# Altium schematic sheet Power_Sensing.SchDoc(Power_Sensing)
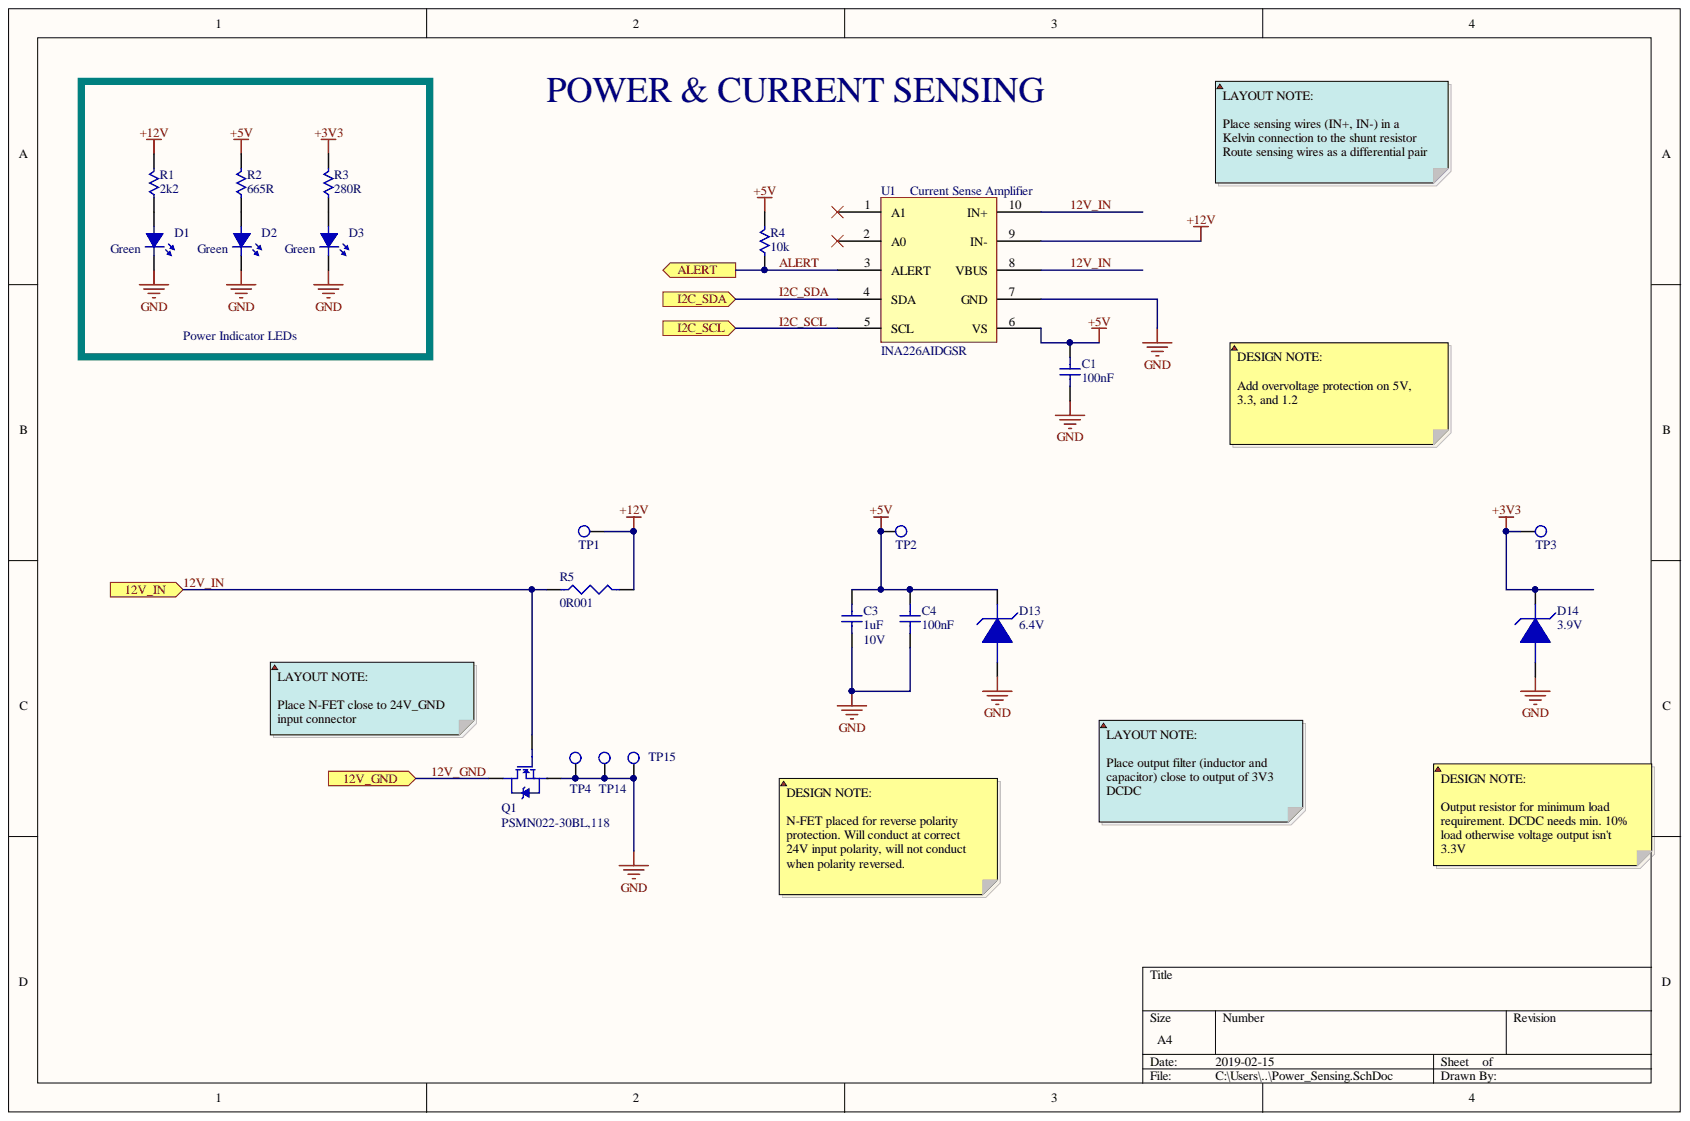
[diagram p0: Power_Sensing.SchDoc]

## Parts
C1  100nF  [C0402]
C3  1uF  Voltage Rating (DC): 10V  [C0402]
C4  100nF  [C0402]
D1  Green  [LED_0603]
D2  Green  [LED_0603]
D3  Green  [LED_0603]
D13  6.4V  [DIODE_TVS]
D14  3.9V  [DIODE_TVS]
Q1  PSMN022-30BL,118  [D2PAK_FETs]
R1  2k2  [R0402]
R2  665R  [R0402]
R3  280R  [R0402]
R4  10k  [R0402]
R5  0R001  [R2512]
TP1  5015  [TP_HOOK]  (value not drawn)
TP2  5015  [TP_HOOK]  (value not drawn)
TP3  5015  [TP_HOOK]  (value not drawn)
TP4  5015  [TP_HOOK]  (value not drawn)
TP14  5015  [TP_HOOK]  (value not drawn)
TP15  5015  [TP_HOOK]  (value not drawn)
U1  INA226AIDGSR  [10-VSSOP_INA226]

## Ports
12V_GND, 12V_IN, ALERT, I2C_SCL, I2C_SDA

## Nets
+3V3: D14.1 R3.2 TP3.1
+5V: C1.2 C3.2 C4.2 D13.1 R2.2 R4.2 TP2.1 U1.6(VS)
+12V: R1.2 R5.2 TP1.1 U1.9(IN-)
GND: C1.1 C3.1 C4.1 D1.2 D2.2 D3.2 D13.2 D14.2 Q1.3 TP4.1 TP14.1 TP15.1 U1.7(GND)
12V_GND: Q1.4 ; port 12V_GND
12V_IN: Q1.1 R5.1 U1.8(VBUS) U1.10(IN+) ; port 12V_IN
ALERT: R4.1 U1.3(ALERT) ; port ALERT
I2C_SCL: U1.5(SCL) ; port I2C_SCL
I2C_SDA: U1.4(SDA) ; port I2C_SDA
NetD1_1: D1.1 R1.1
NetD2_1: D2.1 R2.1
NetD3_1: D3.1 R3.1

Not connected: U1 1(A1) 2(A0)

## Library data of the parts
C1 C4 = CL05A104MP5NNNC: CAP MLCC 0.1uF 10V X5R 0402 20% SMD 0402 85C Paper T/R; Manufacturer: Samsung; Width: 0.02inch; Voltage Rating (DC): 10V; Voltage Rating: 10V; Tolerance: 20%; Thickness: 0.022inch; Supplier Part Number 1: 1276-1443-1-ND; Supplier 1: Digi-Key; Stock 1: 100000; Radiation Hardening: No; Pricing 1: 1=0.1, 10=0.024, 100=0.0106, 500=0.0076, 1000=0.006, 2500=0.0054, 5000=0.005 (USD); Packaging: Tape and Reel; Mount: Surface Mount; Min Operating Temperature: -55°C; Max Operating Temperature: 85°C; Manufacturer Part Number: CL05A104MP5NNNC; Length: 1mm; Lead Free: Lead Free; Height: 0.5mm; Dielectric: X5R; Depth: 0.5mm; ComponentLink1URL: https://media.digikey.com/pdf/Data%20Sheets/Samsung%20PDFs/CL_Series_MLCC_ds.pdf; ComponentLink1Description: https://media.digikey.com/pdf/Data%20Sheets/Samsung%20PDFs/CL_Series_MLCC_ds.pdf; Component Kind: Standard; Case Code (Metric): 1005; Case Code (Imperial): 0402; Case/Package: 0402; Capacitance: 100nF; Library Name: PHANTOM_LIBRARY.SchLib
C3 = CL05A105MP5NNNC: CAP MLCC 1uF 10V 0402 X5R 20% SMD 0402 85C Paper T/R; Manufacturer: Samsung; Width: 0.02inch; Voltage Rating: 10V; Tolerance: 20%; Thickness: 0.022inch; Supplier Part Number 1: 1276-1448-1-ND; Supplier 1: Digi-Key; Stock 1: 100000; Radiation Hardening: No; Pricing 1: 1=0.1, 10=0.031, 100=0.014, 500=0.01, 1000=0.0079, 2500=0.0072, 5000=0.0066 (USD); Packaging: Tape and Reel; Package Quantity: 1; Mount: Surface Mount; Min Operating Temperature: -55°C; Max Operating Temperature: 85°C; Manufacturer Part Number: CL05A105MP5NNNC; Length: 1mm; Lead Free: Lead Free; Height: 0.5mm; Dielectric: X5R; Depth: 0.5mm; ComponentLink1URL: https://media.digikey.com/pdf/Data%20Sheets/Samsung%20PDFs/CL_Series_MLCC_ds.pdf; ComponentLink1Description: https://media.digikey.com/pdf/Data%20Sheets/Samsung%20PDFs/CL_Series_MLCC_ds.pdf; Component Kind: Standard; Case Code (Metric): 1005; Case Code (Imperial): 0402; Case/Package: 0402; Capacitance: 1uF; Library Name: PHANTOM_LIBRARY.SchLib
D1-D3 = 150060VS75000: LED GREEN CLEAR 0603 SMD  LED, 0603, B GREEN,  40MCD, 570NM; Manufacturer: Wurth Electronics; Viewing Angle: 140°; Test Current: 20mA; Lens Style: Clear,Rectangular; Forward Voltage: 2V; Forward Current: 30mA; Width: 0.8mm; Wavelength: 570nm; Supplier Part Number 1: 732-4980-1-ND; Supplier 1: Digi-Key; Stock 1: 207370; REACH SVHC: Unknown; Pricing 1: 1=0.14, 50=0.129, 100=0.11, 500=0.095 (USD); Packaging: Tape and Reel; Package Quantity: 1; Number of Pins: 2; Mount: Surface Mount; Min Operating Temperature: -40°C; Max Operating Temperature: 85°C; Manufacturer Part Number: 150060VS75000; Luminous Intensity: 40mcd; Length: 1.6mm; Height: 0.7mm; Dominant Wavelength: 570nm; ComponentLink4URL: http://www.produktinfo.conrad.com/datenblaetter/750000-774999/759431-da-01-en-CHIPLED_0603_W_KL_H_GRUEN_150060VS7500.pdf; ComponentLink4Description: http://www.produktinfo.conrad.com/datenblaetter/750000-774999/759431-da-01-en-CHIPLED_0603_W_KL_H_GRUEN_150060VS7500.pdf; ComponentLink3URL: https://www.distrelec.biz/Web/Downloads/_t/ds/150060VS75000_eng_tds.pdf?mime=application%2Fpdf; ComponentLink3Description: https://www.distrelec.biz/Web/Downloads/_t/ds/150060VS75000_eng_tds.pdf?mime=application%2Fpdf; ComponentLink2URL: https://katalog.we-online.de/led/datasheet/150060VS75000.pdf; ComponentLink2Description: https://katalog.we-online.de/led/datasheet/150060VS75000.pdf; ComponentLink1URL: http://www.farnell.com/datasheets/1911347.pdf; ComponentLink1Description: http://www.farnell.com/datasheets/1911347.pdf; Component Kind: Standard; Color: Green; Case Code (Metric): 1608; Case Code (Imperial): 0603; Case/Package: 0603; Library Name: PHANTOM_LIBRARY.SchLib
D13 = SMAJ5.0A: DIODE TVS_6V4 5VWM 9.2VC SMA; Manufacturer: Littelfuse; Voltage Rating (DC): 5V; Test Current: 10mA; Power Line Protection: No; Power Dissipation: 400W; Polarity: Unidirectional; Peak Pulse Current: 43.5A; Number of Unidirectional Channels: 1; Max Reverse Leakage Current: 800uA; Max Power Dissipation: 3.3W; Max Breakdown Voltage: 7V; Element Configuration: Single; Clamping Voltage: 9.2V; Width: 2.79mm; Termination: SMD/SMT; Supplier Part Number 1: SMAJ5.0ALFCT-ND; Supplier 1: Digi-Key; Stock 1: 78251; Reverse Standoff Voltage: 5V; Reverse Breakdown Voltage: 6.4V; REACH SVHC: No SVHC; Radiation Hardening: No; Pricing 1: 1=0.51, 10=0.359, 25=0.2948, 100=0.2359, 250=0.1716, 500=0.1394, 1000=0.1072, 2500=0.0965 (USD); Peak Pulse Power: 400W; Packaging: Tape and Reel; Package Quantity: 5000; Operating Supply Voltage: 5V; Number of Pins: 2; Number of Elements: 1; Number of Channels: 1; Mount: Surface Mount; Min Operating Temperature: -65°C; Min Breakdown Voltage: 6.4V; Max Surge Current: 43.5A; Max Operating Temperature: 150°C; Manufacturer Part Number: SMAJ5.0A; Length: 4.5mm; Leakage Current: 800uA; Lead Free: Lead Free; Height: 2.087mm; Direction: Unidirectional; ComponentLink8URL: https://datasheet.ciiva.com/8062/littelfuse-tvs-diode-smaj-8062271.pdf; ComponentLink8Description: https://datasheet.ciiva.com/8062/littelfuse-tvs-diode-smaj-8062271.pdf; ComponentLink7URL: https://datasheet.ciiva.com/4507/littelfuse-tvs-diode-smaj-23503-4507964.pdf; ComponentLink7Description: https://datasheet.ciiva.com/4507/littelfuse-tvs-diode-smaj-23503-4507964.pdf; ComponentLink6URL: https://datasheet.ciiva.com/28473/607617-28473433.pdf; ComponentLink6Description: https://datasheet.ciiva.com/28473/607617-28473433.pdf; ComponentLink5URL: https://datasheet.ciiva.com/25624/0900766b810f4edb-25624839.pdf; ComponentLink5Description: https://datasheet.ciiva.com/25624/0900766b810f4edb-25624839.pdf; ComponentLink4URL: https://datasheet.ciiva.com/16380/littelfuse-tvs-diode-smaj-16380982.pdf; ComponentLink4Description: https://datasheet.ciiva.com/16380/littelfuse-tvs-diode-smaj-16380982.pdf; ComponentLink3URL: https://datasheet.ciiva.com/330/littelfuse-tvs-diode-smaj-datasheet-pdf-330726.pdf; ComponentLink3Description: https://datasheet.ciiva.com/330/littelfuse-tvs-diode-smaj-datasheet-pdf-330726.pdf; ComponentLink2URL: https://datasheet.ciiva.com/330/littelfuse-tvs-diode-smaj-datasheet-pdf-330725.pdf; ComponentLink2Description: https://datasheet.ciiva.com/330/littelfuse-tvs-diode-smaj-datasheet-pdf-330725.pdf; ComponentLink1URL: https://datasheet.ciiva.com/330/littelfuse-tvs-diode-smaj-datasheet-pdf-330724.pdf; ComponentLink1Description: https://datasheet.ciiva.com/330/littelfuse-tvs-diode-smaj-datasheet-pdf-330724.pdf; Component Kind: Standard; Breakdown Voltage: 6.4V; Library Name: PHANTOM_LIBRARY.SchLib
D14 = 1SMA5915BT3G: DIODE ZENER 3.9V 1.5W SMA; Manufacturer: ON Semiconductor; Zener Current: 385mA; Voltage Tolerance: 5%; Test Current: 96.1mA; Power Dissipation: 500mW; Peak Reverse Current: 12.5uA; Impedance: 7.5R; Forward Voltage: 1.5V; ESD Protection: Yes; Element Configuration: Single; Breakdown Voltage: 12V; Zener Voltage: 3.9V; Working Voltage: 3.9V; Width: 2.92mm; Voltage Rating (DC): 3.9V; Tolerance: 5%; Supplier Part Number 1: 1SMA5915BT3GOSCT-ND; Supplier 1: Digi-Key; Stock 1: 21605; REACH SVHC: No SVHC; Radiation Hardening: No; Pricing 1: 1=0.41, 10=0.335, 100=0.2284, 500=0.1713, 1000=0.1285 (USD); Power Rating: 1.5W; Packaging: Tape and Reel; Package Quantity: 1; Number of Pins: 2; Min Operating Temperature: -65°C; Max Reverse Leakage Current: 12.5uA; Max Power Dissipation: 1.5W; Max Operating Temperature: 150°C; Manufacturer Part Number: 1SMA5915BT3G; Length: 4.57mm; Lead Free: Lead Free; Height: 2.05mm; Halogen Free: Halogen Free; Contact Plating: Tin,Matte; ComponentLink7URL: https://datasheet.ciiva.com/10639/1sma5913bt3-d-10639017.pdf; ComponentLink7Description: https://datasheet.ciiva.com/10639/1sma5913bt3-d-10639017.pdf; ComponentLink6URL: https://datasheet.ciiva.com/16311/1sma5913bt3-d-16311880.pdf; ComponentLink6Description: https://datasheet.ciiva.com/16311/1sma5913bt3-d-16311880.pdf; ComponentLink5URL: https://datasheet.ciiva.com/8026/1sma5913bt3-d-8026919.pdf; ComponentLink5Description: https://datasheet.ciiva.com/8026/1sma5913bt3-d-8026919.pdf; ComponentLink4URL: https://datasheet.ciiva.com/895/1sma5913bt3-d-895335.pdf; ComponentLink4Description: https://datasheet.ciiva.com/895/1sma5913bt3-d-895335.pdf; ComponentLink3URL: https://datasheet.ciiva.com/895/1sma5913bt3-d-895334.pdf; ComponentLink3Description: https://datasheet.ciiva.com/895/1sma5913bt3-d-895334.pdf; ComponentLink2URL: https://datasheet.ciiva.com/26092/1sma5913bt3-d-26092405.pdf; ComponentLink2Description: https://datasheet.ciiva.com/26092/1sma5913bt3-d-26092405.pdf; ComponentLink1URL: https://datasheet.ciiva.com/895/1sma5913bt3-d-895333.pdf; ComponentLink1Description: https://datasheet.ciiva.com/895/1sma5913bt3-d-895333.pdf; Component Kind: Standard; Case/Package: SMA; Library Name: PHANTOM_LIBRARY.SchLib
Q1 = PSMN022-30BL,118: MOSFET N-CH 30V 30A 22.6mOhm Rds(ON); Manufacturer: NXP Semiconductors; Turn-On Delay Time: 12ns; Turn-Off Delay Time: 17ns; Rise Time: 29ns; Rds On Max: 22.6mR; Power Dissipation: 41W; Number of Elements: 1; Input Capacitance: 447pF; Gate to Source Voltage (Vgs): 20V; Fall Time: 7ns; Element Configuration: Single; Supplier Part Number 1: 1727-7117-1-ND; Supplier 1: Digi-Key; Stock 1: 8400; Radiation Hardening: No; Pricing 1: 1=0.99, 10=0.867, 100=0.6687 (USD); Packaging: Tape and Reel; Package Quantity: 1; Number of Pins: 3; Min Operating Temperature: -55°C; Max Power Dissipation: 41W; Max Operating Temperature: 175°C; Max Dual Supply Voltage: 30V; Manufacturer Part Number: PSMN022-30BL,118; Lead Free: Lead Free; Drain to Source Voltage (Vdss): 30V; Drain to Source Resistance: 22.6mR; Drain to Source Breakdown Voltage: 30V; Continuous Drain Current (ID): 30A; Contact Plating: Tin; ComponentLink1URL: http://www.nxp.com/documents/data_sheet/PSMN022-30BL.pdf; ComponentLink1Description: http://www.nxp.com/documents/data_sheet/PSMN022-30BL.pdf; Component Kind: Standard; Case/Package: SOT; Library Name: PHANTOM_LIBRARY.SchLib
R1 = RC0402JR-072K2L: RES SMD 2.2K OHM 5% 1/16W 0402; Manufacturer: Yageo; Working Voltage: 50V; Temperature Coefficient: 100ppm/°C; Resistance: 2.2kR; Power Rating: 63mW; Width: 0.02inch; Weight: 2.3E-05oz; Voltage Rating: 50V; Tolerance: 5%; Termination: SMD/SMT; Supplier Part Number 1: 311-2.2KJRCT-ND; Supplier 1: Digi-Key; Stock 1: 7890813; REACH SVHC: Unknown; Pricing 1: 1=0.1, 10=0.018, 100=0.0073, 1000=0.0033, 2500=0.0029, 5000=0.0024 (USD); Packaging: Tape and Reel; Package Quantity: 1; Number of Terminations: 2; Min Operating Temperature: -55°C; Military Standard: Not; Max Power Dissipation: 63mW; Max Operating Temperature: 155°C; Manufacturer Part Number: RC0402JR-072K2L; Length: 1mm; Lead Free: Lead Free; Height: 0.016inch; Features: Moisture Resistant; Depth: 0.5mm; Composition: Thick Film; Component Kind: Standard; Case Code (Metric): 1005; Case Code (Imperial): 0402; Case/Package: 0402; Library Name: PHANTOM_LIBRARY.SchLib
R2 = RC0402FR-07665RL: RES SMD 665 OHM 1% 0402 1/16W; Manufacturer: Yageo; Voltage Rating: 50V; Temperature Coefficient: 100ppm/°C; Power Rating: 62.5mW; Max Power Dissipation: 63mW; Width: 0.02inch; Tolerance: 1%; Termination: SMD/SMT; Supplier Part Number 1: YAG3208CT-ND; Supplier 1: Digi-Key; Stock 1: 268997; Resistance: 665R; Pricing 1: 1=0.1, 10=0.016, 100=0.0067, 1000=0.003, 2500=0.0026, 5000=0.0022 (USD); Packaging: Tape and Reel; Number of Terminations: 2; Min Operating Temperature: -55°C; Military Standard: Not; Max Operating Temperature: 155°C; Manufacturer Part Number: RC0402FR-07665RL; Length: 1mm; Lead Free: Lead Free; Height: 0.016inch; Features: Moisture Resistant; Depth: 0.5mm; Contact Plating: Matte,Tin; Composition: Thick Film; ComponentLink1URL: http://www.yageo.com/documents/recent/PYu-RC0402_51_RoHS_L_6.pdf; ComponentLink1Description: http://www.yageo.com/documents/recent/PYu-RC0402_51_RoHS_L_6.pdf; Component Kind: Standard; Case Code (Metric): 1005; Case Code (Imperial): 0402; Case/Package: 0402; Library Name: PHANTOM_LIBRARY.SchLib
R3 = RC0402FR-07280RL: RES SMD 280 OHM 1% 1/16W 0402; Manufacturer: Yageo; Voltage Rating (DC): 50V; Temperature Coefficient: 100ppm/°C; Power Rating: 62.5mW; Max Power Dissipation: 63mW; Width: 0.5mm; Weight: 2.3E-05oz; Voltage Rating: 50V; Tolerance: 1%; Termination: SMD/SMT; Supplier Part Number 1: 311-280LRCT-ND; Supplier 1: Digi-Key; Stock 1: 282493; Resistance: 280R; Pricing 1: 1=0.1, 10=0.016, 100=0.0067, 1000=0.003, 2500=0.0026, 5000=0.0022 (USD); Packaging: Tape and Reel; Package Quantity: 1; Number of Terminations: 2; Min Operating Temperature: -55°C; Military Standard: Not; Max Operating Temperature: 155°C; Manufacturer Part Number: RC0402FR-07280RL; Length: 1mm; Lead Free: Lead Free; Height: 0.016inch; Features: Moisture Resistant; Depth: 0.5mm; Composition: Thick Film; ComponentLink3URL: http://www.yageo.com/documents/recent/PYu-RC0402_51_RoHS_L_6_r.pdf; ComponentLink3Description: http://www.yageo.com/documents/recent/PYu-RC0402_51_RoHS_L_6_r.pdf; ComponentLink2URL: http://www.yageo.com/documents/recent/PYu-RC_Group_51_RoHS_L_9.pdf; ComponentLink2Description: http://www.yageo.com/documents/recent/PYu-RC_Group_51_RoHS_L_9.pdf; ComponentLink1URL: http://www.yageo.com.tw/exep/pages/download/literatures/PYu-R_INT-thick_7.pdf; ComponentLink1Description: http://www.yageo.com.tw/exep/pages/download/literatures/PYu-R_INT-thick_7.pdf; Component Kind: Standard; Case Code (Metric): 1005; Case Code (Imperial): 0402; Case/Package: 0402; Library Name: PHANTOM_LIBRARY.SchLib
R4 = RC0402JR-0710KL: RES SMD 10K OHM 5% 1/16W 0402; Manufacturer: Yageo; Voltage Rating: 50V; Temperature Coefficient: 100ppm/°C; Resistance: 10kR; Power Rating: 62.5mW; Max Power Dissipation: 63mW; Width: 0.02inch; Tolerance: 5%; Termination: SMD/SMT; Supplier Part Number 1: 311-10KJRCT-ND; Supplier 1: Digi-Key; Stock 1: 47303622; REACH SVHC: Unknown; Pricing 1: 1=0.1, 10=0.018, 100=0.0073, 1000=0.0033, 2500=0.0029, 5000=0.0024 (USD); Packaging: Tape and Reel; Package Quantity: 1; Number of Terminations: 2; Min Operating Temperature: -55°C; Military Standard: Not; Max Operating Temperature: 155°C; Manufacturer Part Number: RC0402JR-0710KL; Length: 1mm; Lead Free: Lead Free; Height: 0.016inch; Features: Moisture Resistant; Depth: 0.5mm; Composition: Thick Film; Component Kind: Standard; Case Code (Metric): 1005; Case Code (Imperial): 0402; Case/Package: 0402; Library Name: PHANTOM_LIBRARY.SchLib
R5 = ERJ-M1WTF1M0U: Res Thick Film 2512 0.001 Ohm 1% 1W 250ppm/C to 450ppm/C Molded SMD Embossed Carrier T/R; Manufacturer: Panasonic ECG; Temperature Coefficient: 450ppm/°C; Resistance: 1mR; Width: 0.126inch; Tolerance: 1%; Termination: SMD/SMT; Supplier Part Number 1: P1.0NDCT-ND; Supplier 1: Digi-Key; Stock 1: 36740; REACH SVHC: No SVHC; Radiation Hardening: No; Pricing 1: 1=0.55, 10=0.456, 25=0.3908, 50=0.3516, 100=0.3061, 250=0.2735, 500=0.2214, 1000=0.1791 (USD); Power Rating: 1W; Packaging: Tape and Reel; Package Quantity: 1; Number of Terminations: 2; Number of Pins: 2; Mount: Surface Mount; Min Operating Temperature: -55°C; Military Standard: Not; Max Power Dissipation: 1W; Max Operating Temperature: 170°C; Manufacturer Part Number: ERJ-M1WTF1M0U; Length: 6.4mm; Lead Free: Lead Free; Height: 0.8mm; Depth: 3.2mm; Contact Plating: Tin; Composition: Thick Film,Metal Strip,Metal Element; ComponentLink11URL: https://datasheet.ciiva.com/16785/70260812-16785566.pdf; ComponentLink11Description: https://datasheet.ciiva.com/16785/70260812-16785566.pdf; ComponentLink10URL: https://datasheet.ciiva.com/30206/aoa0000ce7-30206797.pdf; ComponentLink10Description: https://datasheet.ciiva.com/30206/aoa0000ce7-30206797.pdf; ComponentLink9URL: https://datasheet.ciiva.com/17215/aoa0000ce7-17215229.pdf; ComponentLink9Description: https://datasheet.ciiva.com/17215/aoa0000ce7-17215229.pdf; ComponentLink8URL: https://datasheet.ciiva.com/5996/39800-5996507.pdf; ComponentLink8Description: https://datasheet.ciiva.com/5996/39800-5996507.pdf; ComponentLink7URL: https://datasheet.ciiva.com/16788/70260812-16788394.pdf; ComponentLink7Description: https://datasheet.ciiva.com/16788/70260812-16788394.pdf; ComponentLink6URL: https://datasheet.ciiva.com/16512/aoa0000ce7-16512553.pdf; ComponentLink6Description: https://datasheet.ciiva.com/16512/aoa0000ce7-16512553.pdf; ComponentLink5URL: https://datasheet.ciiva.com/24072/aoa0000ce7-60136-24072248.pdf; ComponentLink5Description: https://datasheet.ciiva.com/24072/aoa0000ce7-60136-24072248.pdf; ComponentLink4URL: https://datasheet.ciiva.com/16216/aoa0000ce7-16216983.pdf; ComponentLink4Description: https://datasheet.ciiva.com/16216/aoa0000ce7-16216983.pdf; ComponentLink3URL: https://datasheet.ciiva.com/7777/39800-7777943.pdf; ComponentLink3Description: https://datasheet.ciiva.com/7777/39800-7777943.pdf; ComponentLink2URL: https://datasheet.ciiva.com/9198/aoa0000ce7-9198885.pdf; ComponentLink2Description: https://datasheet.ciiva.com/9198/aoa0000ce7-9198885.pdf; ComponentLink1URL: https://datasheet.ciiva.com/11811/0900766b80befc3b-11811491.pdf; ComponentLink1Description: https://datasheet.ciiva.com/11811/0900766b80befc3b-11811491.pdf; Component Kind: Standard; Case Code (Metric): 6432; Case Code (Imperial): 2512; Case/Package: 2512; Library Name: PHANTOM_LIBRARY.SchLib
TP1-TP4 TP14 TP15 = 5015: TEST POINT, PCB, SMT; Manufacturer: Keystone Electronics; Number of Ports: 1; Thickness: 0.01inch; Termination: Solder; Supplier Part Number 1: 36-5015CT-ND; Supplier 1: Digi-Key; Stock 1: 1937775; REACH SVHC: No SVHC; Pricing 1: 1=0.44, 10=0.421, 25=0.3604, 50=0.3064, 100=0.2944, 250=0.2644, 500=0.2524 (USD); Packaging: Tape and Reel; Package Quantity: 1; Orientation: Straight; Mount: Surface Mount; Material: Bronze,Silver; Manufacturer Part Number: 5015; Length: 2.66mm; Lead Free: Lead Free; Height: 0.06inch; Depth: 1.01mm; Contact Plating: Tin,Silver,Lead; Contact Material: Bronze; ComponentLink4URL: https://datasheet.ciiva.com/16883/70182998-16883734.pdf; ComponentLink4Description: https://datasheet.ciiva.com/16883/70182998-16883734.pdf; ComponentLink3URL: https://datasheet.ciiva.com/1123/5015-1123862.pdf; ComponentLink3Description: https://datasheet.ciiva.com/1123/5015-1123862.pdf; ComponentLink2URL: https://datasheet.ciiva.com/2917/5015-2917617.pdf; ComponentLink2Description: https://datasheet.ciiva.com/2917/5015-2917617.pdf; ComponentLink1URL: https://datasheet.ciiva.com/2917/5015-2917616.pdf; ComponentLink1Description: https://datasheet.ciiva.com/2917/5015-2917616.pdf; Component Kind: Standard; Colour: White; Library Name: PHANTOM_LIBRARY.SchLib
U1 = INA226AIDGSR: IC MONITOR PWR/CURR BIDIR 10MSOP; Manufacturer: Texas Instruments; Output Current: 10mA; Nominal Supply Current: 330uA; Min Supply Voltage: 2.7V; Max Supply Voltage: 5.5V; Input Offset Voltage (Vos): 2.5uV; Input Bias Current: 10uA; Common Mode Rejection Ratio: 140dB; Accuracy: 0.02%; Supplier Part Number 1: 296-29034-1-ND; Supplier 1: Digi-Key; Stock 1: 62674; REACH SVHC: No SVHC; Radiation Hardening: No; Pricing 1: 1=2.95, 10=2.648, 100=2.1692, 500=1.8466, 1000=1.5574 (USD); Packaging: Tape and Reel; Package Quantity: 1; Number of Pins: 10; Mount: Surface Mount; Min Operating Temperature: -40°C; Max Operating Temperature: 125°C; Manufacturer Part Number: INA226AIDGSR; Lead Free: Lead Free; Contact Plating: Gold; ComponentLink6URL: https://datasheet.ciiva.com/22693/1840205-22693516.pdf; ComponentLink6Description: https://datasheet.ciiva.com/22693/1840205-22693516.pdf; ComponentLink5URL: https://datasheet.ciiva.com/4875/sbos547-126280-4875559.pdf; ComponentLink5Description: https://datasheet.ciiva.com/4875/sbos547-126280-4875559.pdf; ComponentLink4URL: https://datasheet.ciiva.com/14196/ina226-14196741.pdf; ComponentLink4Description: https://datasheet.ciiva.com/14196/ina226-14196741.pdf; ComponentLink3URL: https://datasheet.ciiva.com/1939/ina226-1939635.pdf; ComponentLink3Description: https://datasheet.ciiva.com/1939/ina226-1939635.pdf; ComponentLink2URL: https://datasheet.ciiva.com/1939/ina226-1939634.pdf; ComponentLink2Description: https://datasheet.ciiva.com/1939/ina226-1939634.pdf; ComponentLink1URL: https://datasheet.ciiva.com/1939/ina226-1939633.pdf; ComponentLink1Description: https://datasheet.ciiva.com/1939/ina226-1939633.pdf; Component Kind: Standard; Case/Package: VSSOP; Library Name: PHANTOM_LIBRARY.SchLib
